# Altium schematic sheet DSP.SchDoc(DSP)
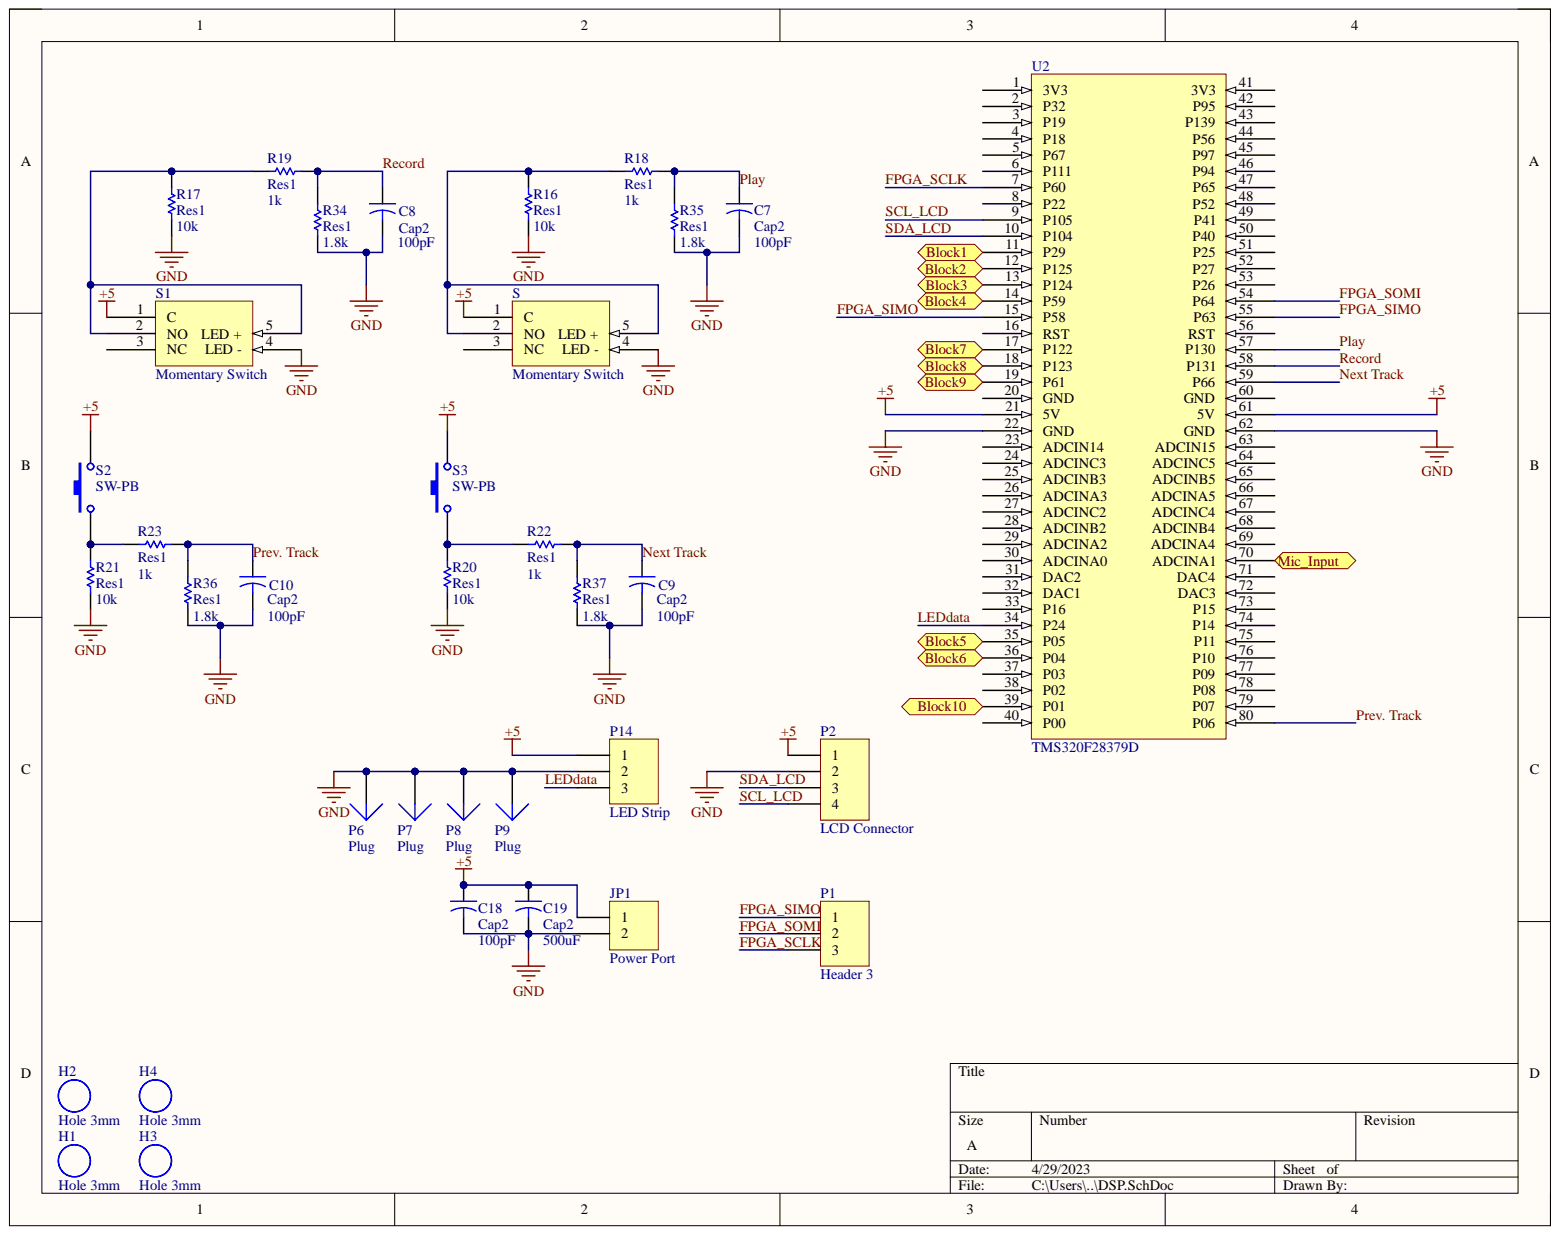
[diagram p0: DSP.SchDoc]

## Parts
C7  Cap2  value 100pF  [CAPR5-4X5]
C8  Cap2  value 100pF  [CAPR5-4X5]
C9  Cap2  value 100pF  [CAPR5-4X5]
C10  Cap2  value 100pF  [CAPR5-4X5]
C18  Cap2  value 100pF  [CAPR5-4X5]
C19  Cap2  value 500uF  [CAPR5-4X5]
H1  Hole 3mm  [Hole 3mm]
H2  Hole 3mm  [Hole 3mm]
H3  Hole 3mm  [Hole 3mm]
H4  Hole 3mm  [Hole 3mm]
JP1  Power Port  [CON2screw]
P1  Header 3  [HDR1X3]
P2  LCD Connector  [HDR1X4]
P6  Plug  [PIN1]
P7  Plug  [PIN1]
P8  Plug  [PIN1]
P9  Plug  [PIN1]
P14  LED Strip  [HDR1X3]
R16  Res1  value 10k  [AXIAL-0.3]
R17  Res1  value 10k  [AXIAL-0.3]
R18  Res1  value 1k  [AXIAL-0.3]
R19  Res1  value 1k  [AXIAL-0.3]
R20  Res1  value 10k  [AXIAL-0.3]
R21  Res1  value 10k  [AXIAL-0.3]
R22  Res1  value 1k  [AXIAL-0.3]
R23  Res1  value 1k  [AXIAL-0.3]
R34  Res1  value 1.8k  [AXIAL-0.3]
R35  Res1  value 1.8k  [AXIAL-0.3]
R36  Res1  value 1.8k  [AXIAL-0.3]
R37  Res1  value 1.8k  [AXIAL-0.3]
S  Momentary Switch  [HDR1X5]
S1  Momentary Switch  [HDR1X5]
S2  SW-PB  [SPST-2]
S3  SW-PB  [SPST-2]
U2  TMS320F28379D  [LaunchXL_F28379D]

## Ports
Block1, Block2, Block3, Block4, Block5, Block6, Block7, Block8, Block9, Block10, Mic_Input

## Nets
+5: C18.1 C19.1 JP1.1 P2.1 P14.1 S.1(C) S1.1(C) S2.2 S3.2 U2.21(5V) U2.61(5V)
GND: C7.2 C8.2 C9.2 C10.2 C18.2 C19.2 JP1.2 P2.2 P6.1 P7.1 P8.1 P9.1 P14.2 R16.1 R17.1 R20.1 R21.1 R34.1 R35.1 R36.1 R37.1 S.4(LED_-) S1.4(LED_-) U2.22(GND) U2.62(GND)
Block1: U2.11(P29) ; port Block1
Block2: U2.12(P125) ; port Block2
Block3: U2.13(P124) ; port Block3
Block4: U2.14(P59) ; port Block4
Block5: U2.35(P05) ; port Block5
Block6: U2.36(P04) ; port Block6
Block7: U2.17(P122) ; port Block7
Block8: U2.18(P123) ; port Block8
Block9: U2.19(P61) ; port Block9
Block10: U2.39(P01) ; port Block10
FPGA_SCLK: P1.3 U2.7(P60)
FPGA_SIMO: P1.1 U2.15(P58) U2.55(P63)
FPGA_SOMI: P1.2 U2.54(P64)
LEDdata: P14.3 U2.34(P24)
Mic_Input: U2.70(ADCINA1) ; port Mic_Input
Next Track: C9.1 R22.1 R37.2 U2.59(P66)
Play: C7.1 R18.1 R35.2 U2.57(P130)
Prev. Track: C10.1 R23.1 R36.2 U2.80(P06)
Record: C8.1 R19.1 R34.2 U2.58(P131)
SCL_LCD: P2.4 U2.9(P105)
SDA_LCD: P2.3 U2.10(P104)
NetR16_2: R16.2 R18.2 S.2(NO) S.5(LED_+)
NetR17_2: R17.2 R19.2 S1.2(NO) S1.5(LED_+)
NetR20_2: R20.2 R22.2 S3.1
NetR21_2: R21.2 R23.2 S2.1

Not connected: S 3(NC); S1 3(NC); U2 1(3V3) 2(P32) 3(P19) 4(P18) 5(P67) 6(P111) 8(P22) 16(RST) 20(GND) 23(ADCIN14) 24(ADCINC3) 25(ADCINB3) 26(ADCINA3) 27(ADCINC2) 28(ADCINB2) 29(ADCINA2) 30(ADCINA0) 31(DAC2) 32(DAC1) 33(P16) 37(P03) 38(P02) 40(P00) 41(3V3) 42(P95) 43(P139) 44(P56) 45(P97) 46(P94) 47(P65) 48(P52) 49(P41) 50(P40) 51(P25) 52(P27) 53(P26) 56(RST) 60(GND) 63(ADCIN15) 64(ADCINC5) 65(ADCINB5) 66(ADCINA5) 67(ADCINC4) 68(ADCINB4) 69(ADCINA4) 71(DAC4) 72(DAC3) 73(P15) 74(P14) 75(P11) 76(P10) 77(P09) 78(P08) 79(P07)

## Library data of the parts
C7-C10 C18 C19 = Cap2: Capacitor; Component Kind: Standard; Library Name: Miscellaneous Devices.IntLib; PackageDescription: Capacitor; 2 Leads; PackageReference: CAPR5-4X5; Published: 23-Sep-2002; Signal Integrity: Cap; Simulation: CAP; Publisher: Altium Limited
JP1 = Power: Component Kind: Standard; Library Name: ECE LIB new.SCHLIB
P1 P14 = Header 3: Header, 3-Pin; Component Kind: Standard; LatestRevisionDate: 17-Jul-2002; LatestRevisionNote: Re-released for DXP Platform.; Library Name: Miscellaneous Connectors.IntLib; Signal Integrity: Connector; Publisher: Altium Limited
P2 = Header 4: Header, 4-Pin; Component Kind: Standard; LatestRevisionDate: 17-Jul-2002; LatestRevisionNote: Re-released for DXP Platform.; Library Name: Miscellaneous Connectors.IntLib; Signal Integrity: Connector; Publisher: Altium Limited
R16-R23 R34-R37 = Res1: Resistor; Component Kind: Standard; LatestRevisionDate: 17-Jul-2002; LatestRevisionNote: Re-released for DXP Platform.; Library Name: Miscellaneous Devices.IntLib; PackageDescription: Axial Device, Thru-Hole; 2 Leads; 0.3 in Pin Spacing; PackageReference: AXIAL-0.3; Published: 8-Jun-2000; Signal Integrity: Res; Simulation: RESISTOR; Publisher: Altium Limited
S S1 = Momentary Switch: LED Push Button; Component Kind: Standard; Library Name: ECE LIB new.SCHLIB
S2 S3 = SW-PB: Switch; Component Kind: Standard; LatestRevisionDate: 17-Jul-2002; LatestRevisionNote: Re-released for DXP Platform.; Library Name: Miscellaneous Devices.IntLib; PackageDescription: Switch; 2 Leads; PackageReference: SPST-2; Published: 24-Mar-1999; Publisher: Altium Limited
H1-H4 = Hole 3mm: Component Kind: Standard; Library Name: ECE LIB new.SCHLIB
P6-P9 = Plug: Component Kind: Standard; Description: Plug; LatestRevisionDate: 17-Jul-2002; LatestRevisionNote: Re-released for DXP Platform.; Library Name: Miscellaneous Connectors.IntLib; Signal Integrity: Connector; Publisher: Altium Limited
U2 = TMS320F28379D: Component Kind: Standard; Library Name: LaunchXL_F28379D.SchLib
